# Altium schematic sheet Analog - Current_Probe_V0.2.SchDoc
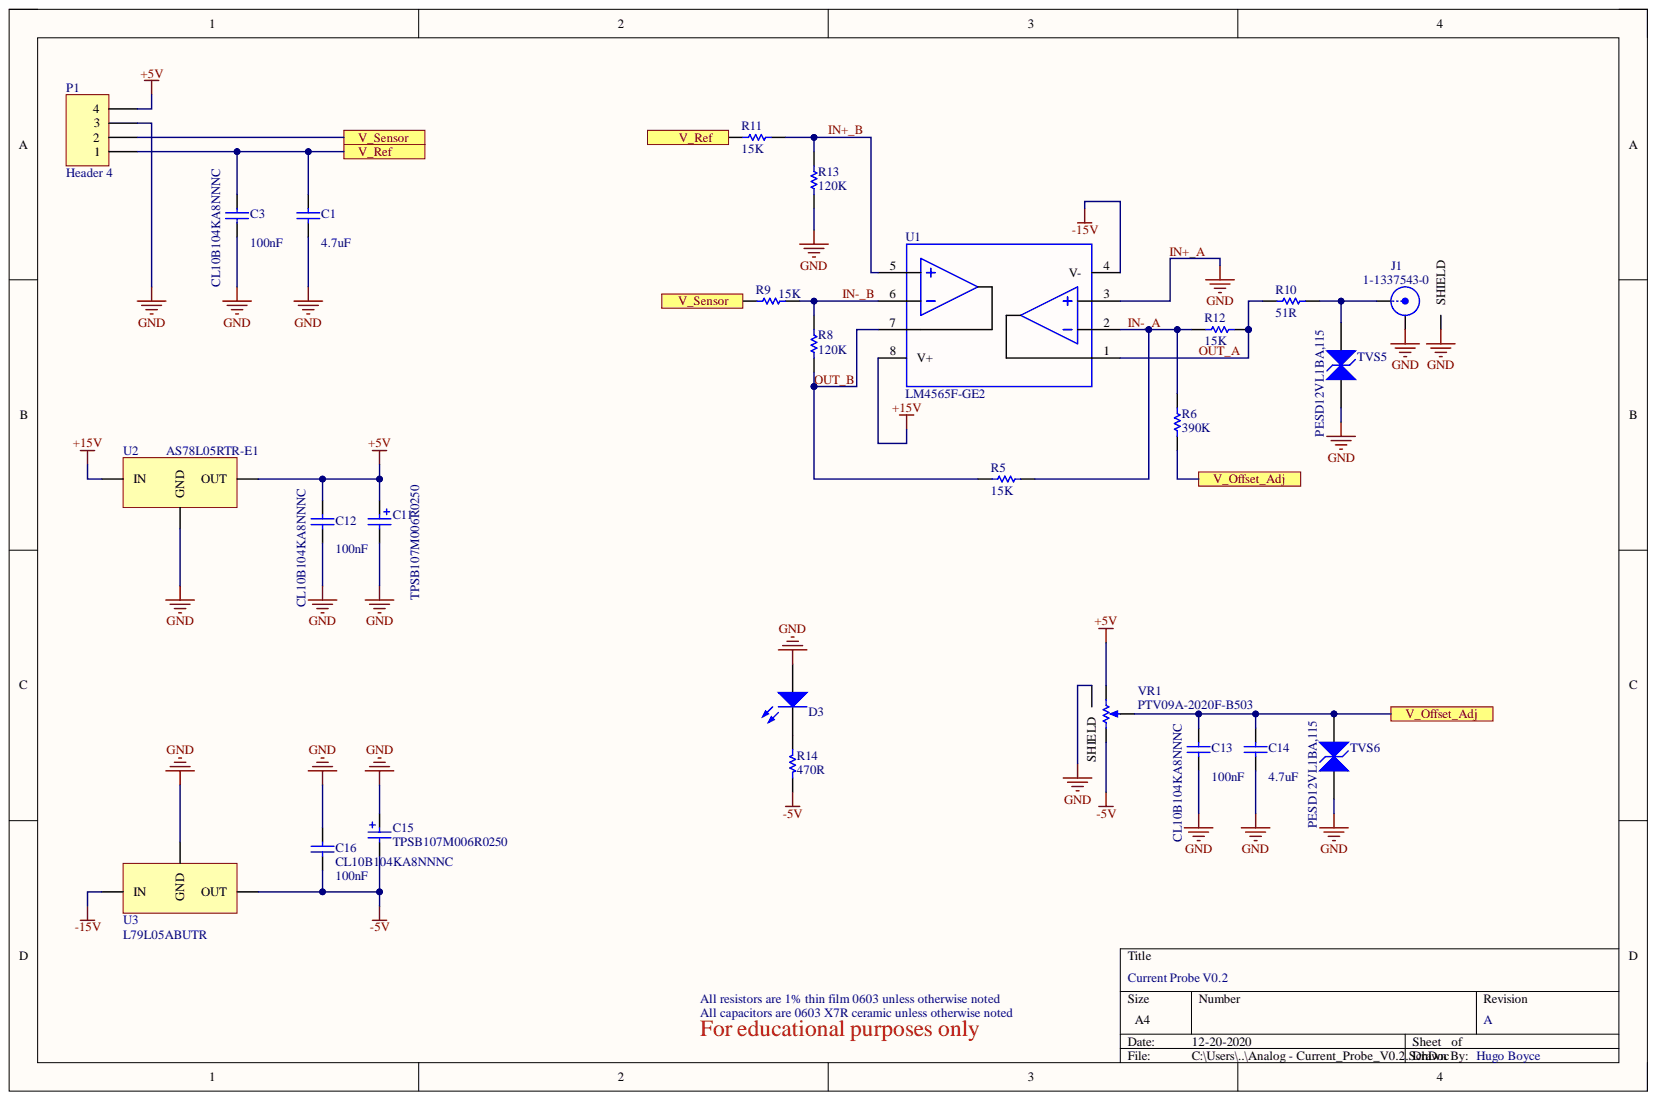
[diagram p0: Analog - Current_Probe_V0.2.SchDoc]

## Parts
C1  value 4.7uF  [CAPC3216N]  (hidden comment: CL31B475KAHNNNE)
C3  CL10B104KA8NNNC  value 100nF  [CAPC1608N]
C11  TPSB107M006R0250  [CAPMP3529X21N]
C12  CL10B104KA8NNNC  value 100nF  [CAPC1608N]
C13  CL10B104KA8NNNC  value 100nF  [CAPC1608N]
C14  value 4.7uF  [CAPC3216N]  (hidden comment: CL31B475KAHNNNE)
C15  TPSB107M006R0250  [CAPMP3529X21N]
C16  CL10B104KA8NNNC  value 100nF  [CAPC1608N]
D3  150120RS75000  [150120RS75000]  (value not drawn)
J1  1-1337543-0  [1-1337543-0]
P1  Header 4  [HDR1X4]
R5  value 15K  [RESC1608N]  (hidden comment: Res1)
R6  value 390K  [RESC1608N]  (hidden comment: Res1)
R8  value 120K  [RESC1608N]  (hidden comment: Res1)
R9  value 15K  [RESC1608N]  (hidden comment: Res1)
R10  value 51R  [RESC3225N]  (hidden comment: Res1)
R11  value 15K  [RESC1608N]  (hidden comment: Res1)
R12  value 15K  [RESC1608N]  (hidden comment: Res1)
R13  value 120K  [RESC1608N]  (hidden comment: Res1)
R14  value 470R  [RESC1608N]  (hidden comment: Res1)
TVS5  PESD12VL1BA,115  [SODFL260X100-2N]
TVS6  PESD12VL1BA,115  [SODFL260X100-2N]
U1  LM4565F-GE2  [SOIC8_LM4565]
U2  AS78L05RTR-E1  [SOT89N]
U3  L79L05ABUTR  [SOT89N]
VR1  PTV09A-2020F-B503  [PTV09A-2x20F]

## Ports
V_Offset_Adj, V_Ref, V_Sensor

## Nets
+5V: C11.1 C12.2 P1.4 U2.1 VR1.3
+15V: U1.8(V+) U2.3
-5V: C15.2 C16.2 R14.1 U3.3 VR1.1
-15V: U1.4(V-) U3.2
GND: C1.1 C3.1 C11.2 C12.1 C13.1 C14.1 C15.1 C16.1 D3.2 J1.2 J1.3 P1.3 R13.1 TVS5.A TVS6.A U1.3 U2.2 U3.1 VR1.4
IN+_B: R11.1 R13.2 U1.5
IN-_A: R5.1 R6.1 R12.1 U1.2
IN-_B: R8.1 R9.1 U1.6
OUT_A: R10.1 R12.2 U1.1
OUT_B: R5.2 R8.2 U1.7
V_Offset_Adj: C13.2 C14.2 R6.2 TVS6.K VR1.2 ; port V_Offset_Adj
V_Ref: C1.2 C3.2 P1.1 R11.2 ; port V_Ref
V_Sensor: P1.2 R9.2 ; port V_Sensor
NetD3_1: D3.1 R14.2
NetJ1_1: J1.1 R10.2 TVS5.K
IN+_A:

## Library data of the parts
C1 C14 = CL31B475KAHNNNE: Cap Ceramic 4.7uF 25V X7R 10% SMD 1206 125C Embossed T/R; Manufacturer: Samsung; Capacitance: 4700000 pF; Case Size/Dimension: 1206; Component Kind: Standard; ComponentLink1Description: http://www.samsungsem.com/kr/support/product-search/mlcc/CL31B475KAHNNNE.jsp?src-supplier=Digi-Key; ComponentLink1URL: http://www.samsungsem.com/kr/support/product-search/mlcc/CL31B475KAHNNNE.jsp?src-supplier=Digi-Key; ComponentLink2Description: https://www.distrelec.biz/Web/Downloads/_e/ng/MLCC_CatalogDownload2013_ENG.pdf?mime=application%2Fpdf&src-supplier=Distrelec; ComponentLink2URL: https://www.distrelec.biz/Web/Downloads/_e/ng/MLCC_CatalogDownload2013_ENG.pdf?mime=application%2Fpdf&src-supplier=Distrelec; ComponentLink3Description: http://www1.futureelectronics.com/doc/SAMSUNG/CL10C1R5BB8NNNC.pdf?src-supplier=Future+Electronics; ComponentLink3URL: http://www1.futureelectronics.com/doc/SAMSUNG/CL10C1R5BB8NNNC.pdf?src-supplier=Future+Electronics; ComponentLink4Description: http://www.produktinfo.conrad.com/datenblaetter/450000-474999/452772-da-01-en-VS_KONDENSATOR_1206.pdf?src-supplier=Conrad; ComponentLink4URL: http://www.produktinfo.conrad.com/datenblaetter/450000-474999/452772-da-01-en-VS_KONDENSATOR_1206.pdf?src-supplier=Conrad; ComponentLink5Description: http://www.produktinfo.conrad.com/datenblaetter/450000-474999/452785-da-01-en-VS_KONDENSATOR_1206.pdf?src-supplier=Conrad; ComponentLink5URL: http://www.produktinfo.conrad.com/datenblaetter/450000-474999/452785-da-01-en-VS_KONDENSATOR_1206.pdf?src-supplier=Conrad; Dielectric: X7R; Manufacturer Part Number: CL31B475KAHNNNE; Pricing 1: 1=0.22, 10=0.152, 50=0.0912, 100=0.077, 500=0.0527, 1000=0.0466 (USD); Rated Voltage: 25 V; Stock 1: 137774; Supplier 1: Digi-Key; Supplier Part Number 1: 1276-1055-1-ND; Tolerance (%): ±10 %; Library Name: Current_Probe_Library.SchLib
C3 C12 C13 C16 = CL10B104KA8NNNC: CAP CER 0.1UF 25V X7R 0603; Manufacturer: Samsung; Capacitance: 100000 pF; Tolerance (%): ±10 %; Case Size/Dimension: 0603; Component Kind: Standard; ComponentLink1Description: http://www.samsungsem.com/kr/support/product-search/mlcc/__icsFiles/afieldfile/2018/08/29/Data%20sheet_CL10B104KA8NNNC.pdf?src-supplier=Digi-Key; ComponentLink1URL: http://www.samsungsem.com/kr/support/product-search/mlcc/__icsFiles/afieldfile/2018/08/29/Data%20sheet_CL10B104KA8NNNC.pdf?src-supplier=Digi-Key; ComponentLink2Description: https://www.verical.com/server-webapp/html/getDatasheet?partID=354050&src-supplier=Verical; ComponentLink2URL: https://www.verical.com/server-webapp/html/getDatasheet?partID=354050&src-supplier=Verical; Dielectric: X7R; Manufacturer Part Number: CL10B104KA8NNNC; Pricing 1: 1=0.1, 10=0.029, 50=0.016, 100=0.013, 500=0.0093, 1000=0.0073 (USD); Rated Voltage: 25 V; Stock 1: 4133737; Supplier 1: Digi-Key; Supplier Part Number 1: 1276-1006-1-ND; Library Name: Current_Probe_Library.SchLib
C11 C15 = TPSB107M006R0250: CAP TANT 100UF 20% 6.3V 1411; Manufacturer: Kyocera AVX; Manufacturer Size Code: B; Capacitance: 100µF; ESR (Equivalent Series Resistance): 250 mOhm; Featured Product: TPS Capacitors; Online Catalog: TPS Series; Other Names: 478-4978-6; Product Training Modules: Component Solutions for Smart Meter Applications; Type: Molded; Category: Capacitors; Component Kind: Standard; ComponentLink1Description: http://datasheets.avx.com/TPS.pdf?src-supplier=Digi-Key; ComponentLink1URL: http://datasheets.avx.com/TPS.pdf?src-supplier=Digi-Key; ComponentLink2Description: http://www.avx.com/docs/catalogs/tps.pdf?src-supplier=Avnet; ComponentLink2URL: http://www.avx.com/docs/catalogs/tps.pdf?src-supplier=Avnet; ComponentLink3Description: http://www.avxcorp.com/docs/Catalogs/tps.pdf?src-supplier=Future+Electronics; ComponentLink3URL: http://www.avxcorp.com/docs/Catalogs/tps.pdf?src-supplier=Future+Electronics; ComponentLink4Description: http://www.avx.com/docs/catalogs/tps.pdf?src-supplier=Avnet+Europe; ComponentLink4URL: http://www.avx.com/docs/catalogs/tps.pdf?src-supplier=Avnet+Europe; Family: Tantalum Capacitors; Features: General Purpose; Height - Seated (Max): 0.083" (2.10mm); Lead Spacing: -; Lifetime @ Temp.: -; Manufacturer Part Number: TPSB107M006R0250; Mounting Type: Surface Mount; Operating Temperature: -55°C ~ 125°C; Package / Case: 1210 (3528 Metric); Packaging: Digi-Reel®; Pricing 1: 1=0.65, 10=0.478, 100=0.3279, 500=0.2596, 1000=0.2186 (USD); Series: TPS; Size / Dimension: 0.138" L x 0.110" W (3.50mm x 2.80mm); Standard Package: 1; Stock 1: 4274; Supplier 1: Digi-Key; Supplier Part Number 1: 478-4978-1-ND; Tolerance: ±20%; Voltage - Rated: 6.3V; Library Name: Current_Probe_Library.SchLib
D3 = 150120RS75000: LED RED CLEAR 1206 SMD; Manufacturer: Wurth Electronics Inc.; Current - Test: 20mA; Lens Color: Colorless; Lens Style/Size: Rectangle with Flat Top, 2.00mm x 1.60mm; Millicandela Rating: 150mcd; Viewing Angle: 140°; Voltage - Forward (Vf) (Typ): 2V; Wavelength - Dominant: 625nm; Wavelength - Peak: 630nm; Category: Optoelectronics; Color: Red; Component Kind: Standard; ComponentLink1Description: http://katalog.we-online.de/led/datasheet/150120RS75000.pdf; ComponentLink1URL: http://katalog.we-online.de/led/datasheet/150120RS75000.pdf; Features: -; Height (Max): 0.69mm; Lens Transparency: Clear; Manufacturer Part Number: 150120RS75000; Mounting Type: Surface Mount; Package / Case: 1206 (3216 Metric); Packaging: Cut Tape (CT); Part Status: Active; Pricing 1: 1=0.27, 50=0.2582, 100=0.2211, 500=0.18952 (CAD); RoHS: RoHS Compliant; Size / Dimension: 3.20mm L x 1.60mm W; Stock 1: 14076; Supplier 1: Digi-Key; Supplier Device Package: 1206; Supplier Part Number 1: 732-4991-1-ND; Library Name: Current_Probe_Library.SchLib
J1 = 1-1337543-0: Conn ZDC/BNC RCP 0Hz to 4GHz 50Ohm Solder RA Thru-Hole Gold Over Nickel Over Copper Individual; Manufacturer: TE Connectivity; Connector Body Style: Right Angle Jack; Connector Mounting: PCB Mount; Connector Type: BNC Coaxial; SVHC: To Be Advised; Coaxial Cable Types: -; Coaxial Termination: Solder; Component Kind: Standard; ComponentLink1Description: http://www.farnell.com/datasheets/580087.pdf?src-supplier=Farnell; ComponentLink1URL: http://www.farnell.com/datasheets/580087.pdf?src-supplier=Farnell; ComponentLink2Description: http://www.farnell.com/datasheets/580087.pdf?src-supplier=Element14; ComponentLink2URL: http://www.farnell.com/datasheets/580087.pdf?src-supplier=Element14; ComponentLink3Description: http://www.alliedelec.com/m/d/1f9c8ff8fec8b9d66c5116e4363293bb.pdf?src-supplier=Allied+Electronics; ComponentLink3URL: http://www.alliedelec.com/m/d/1f9c8ff8fec8b9d66c5116e4363293bb.pdf?src-supplier=Allied+Electronics; ComponentLink4Description: http://www.te.com/commerce/DocumentDelivery/DDEController?Action=showdoc&DocId=Data+Sheet%7F6-1773448-5_ZDC_RF_COAX_50-75_OHM%7F1007%7Fpdf%7FEnglish%7FENG_DS_6-1773448-5_ZDC_RF_COAX_50-75_OHM_1007.pdf&src-supplier=Avnet; ComponentLink4URL: http://www.te.com/commerce/DocumentDelivery/DDEController?Action=showdoc&DocId=Data+Sheet%7F6-1773448-5_ZDC_RF_COAX_50-75_OHM%7F1007%7Fpdf%7FEnglish%7FENG_DS_6-1773448-5_ZDC_RF_COAX_50-75_OHM_1007.pdf&src-supplier=Avnet; ComponentLink5Description: https://www.te.com/commerce/DocumentDelivery/DDEController?Action=srchrtrv&DocNm=1337543&DocType=Customer+Drawing&DocLang=English&src-supplier=Digi-Key; ComponentLink5URL: https://www.te.com/commerce/DocumentDelivery/DDEController?Action=srchrtrv&DocNm=1337543&DocType=Customer+Drawing&DocLang=English&src-supplier=Digi-Key; ComponentLink6Description: http://www.te.com/commerce/DocumentDelivery/DDEController?Action=showdoc&DocId=Data+Sheet%7F6-1773448-5_ZDC_RF_COAX_50-75_OHM%7F1007%7Fpdf%7FEnglish%7FENG_DS_6-1773448-5_ZDC_RF_COAX_50-75_OHM_1007.pdf&src-supplier=Avnet+Europe; ComponentLink6URL: http://www.te.com/commerce/DocumentDelivery/DDEController?Action=showdoc&DocId=Data+Sheet%7F6-1773448-5_ZDC_RF_COAX_50-75_OHM%7F1007%7Fpdf%7FEnglish%7FENG_DS_6-1773448-5_ZDC_RF_COAX_50-75_OHM_1007.pdf&src-supplier=Avnet+Europe; ComponentLink7Description: http://www.onlinecomponents.com/productFiles/mf-AMP/eng_ds_6-1773448-5_zdc_rf_coax_50-75_ohm_1007.pdf?src-supplier=Onlinecomponents.com; ComponentLink7URL: http://www.onlinecomponents.com/productFiles/mf-AMP/eng_ds_6-1773448-5_zdc_rf_coax_50-75_ohm_1007.pdf?src-supplier=Onlinecomponents.com; Contact Material: Brass; Contact Plating: Gold Plated Contacts; Frequency Max: 4GHz; Impedance: 50ohm; Manufacturer Part Number: 1-1337543-0; Pricing 1: 1=1.67, 10=1.514, 25=1.4212, 50=1.3592, 100=1.2975, 250=1.1739, 500=1.0812, 1000=0.9268 (USD); Product Range: -; Stock 1: 4565; Supplier 1: Digi-Key; Supplier Part Number 1: A97553-ND; Library Name: Current_Probe_Library.SchLib
P1 = Header 4: Header, 4-Pin; Component Kind: Standard; LatestRevisionDate: 17-Jul-2002; LatestRevisionNote: Re-released for DXP Platform.; Library Name: Miscellaneous Connectors.IntLib; Signal Integrity: Connector; Publisher: Altium Limited
R5 R6 R8-R14 = Res1: Resistor; Component Kind: Standard; LatestRevisionDate: 17-Jul-2002; LatestRevisionNote: Re-released for DXP Platform.; Library Name: Miscellaneous Devices.SchLib; PackageDescription: Axial Device, Thru-Hole; 2 Leads; 0.3 in Pin Spacing; PackageReference: AXIAL-0.3; Published: 8-Jun-2000; Signal Integrity: Res; Simulation: RESISTOR; Publisher: Altium Limited
TVS5 TVS6 = PESD12VL1BA,115: TVS DIODE 12V 37V SOD323; Manufacturer: Nexperia; Component Kind: Standard; ComponentLink1Description: http://www.farnell.com/datasheets/682594.pdf?src-supplier=Farnell; ComponentLink1URL: http://www.farnell.com/datasheets/682594.pdf?src-supplier=Farnell; ComponentLink2Description: https://assets.nexperia.com/documents/data-sheet/PESD24VL1BA.pdf?src-supplier=Digi-Key; ComponentLink2URL: https://assets.nexperia.com/documents/data-sheet/PESD24VL1BA.pdf?src-supplier=Digi-Key; Manufacturer Part Number: PESD12VL1BA,115; Pricing 1: 1=0.43, 10=0.28, 100=0.156, 500=0.104, 1000=0.072 (USD); Stock 1: 207; Supplier 1: Digi-Key; Supplier Part Number 1: 1727-3808-1-ND; Library Name: Current_Probe_Library.SchLib
U1 = LM4565F-GE2: Op Amp Dual Low Noise Amplifier ±18V 8-Pin SOP T/R; Manufacturer: Rohm; Amplifier Type: General Purpose; Current - Input Bias: 70nA; Current - Output / Channel: 160mA; Current - Supply: 4.5mA; Gain Bandwidth Product: 10MHz; Number of Circuits: 2; Slew Rate: 5 V/µs; Voltage - Input Offset: 500µV; Voltage - Supply, Single/Dual (±): 4 V ~ 36 V, ±2 V ~ 18 V; -3db Bandwidth: -; Category: Integrated Circuits (ICs); Component Kind: Standard; ComponentLink1Description: http://rohmfs.rohm.com/en/products/databook/datasheet/ic/amp_linear/opamp/lm4565f-e.pdf?src-supplier=Digi-Key; ComponentLink1URL: http://rohmfs.rohm.com/en/products/databook/datasheet/ic/amp_linear/opamp/lm4565f-e.pdf?src-supplier=Digi-Key; ComponentLink2Description: http://rohmfs.rohm.com/en/products/databook/datasheet/ic/amp_linear/opamp/lm4565f-e.pdf?src-supplier=Avnet; ComponentLink2URL: http://rohmfs.rohm.com/en/products/databook/datasheet/ic/amp_linear/opamp/lm4565f-e.pdf?src-supplier=Avnet; ComponentLink3Description: http://www.mouser.com/ds/2/348/lm4565f-e-372180.pdf?src-supplier=Mouser; ComponentLink3URL: http://www.mouser.com/ds/2/348/lm4565f-e-372180.pdf?src-supplier=Mouser; Family: Linear - Amplifiers - Instrumentation, OP Amps, Buffer Amps; Manufacturer Part Number: LM4565F-GE2; Mounting Type: Surface Mount; Operating Temperature: -40°C ~ 85°C; Output Type: -; Package / Case: 8-SOIC (0.173", 4.40mm Width); Packaging: Tape & Reel (TR); Pricing 1: 1=0.45, 10=0.385, 25=0.3592, 100=0.2668, 250=0.2257, 500=0.2155, 1000=0.159 (USD); Series: -; Stock 1: 1588; Supplier 1: Digi-Key; Supplier Device Package: 8-SOP; Supplier Part Number 1: LM4565F-GE2CT-ND; Library Name: Current_Probe_Library.SchLib
U2 = AS78L05RTR-E1: IC REG LINEAR 5V 100MA SOT89-3; Manufacturer: Diodes; Accuracy: 5 %; Function: Standard; Junction to Ambient: 180 C/W; Junction to Case: 28.3 C/W; Line Regulation: 150 mV; Load Regulation: 60 mV; Max Processing Temp: 260; Maximum Input Voltage: 30 V; Maximum Output Current: 0.1 A; Maximum Power Dissipation: 750 mW; Maximum Quiescent Current: 5.5 mA; Number of Outputs: 1; Output Type: Fixed; Polarity: Positive; Screening Level: Extended Industrial; Typical Dropout Voltage @ Current: 1.7@40mA|1.8@100mA V; Typical Power Dissipation: 0.75 W; Typical Quiescent Current: 3 mA; Component Kind: Standard; ComponentLink1Description: http://www.diodes.com/datasheets/AS78Lxx.pdf?src-supplier=Avnet; ComponentLink1URL: http://www.diodes.com/datasheets/AS78Lxx.pdf?src-supplier=Avnet; Manufacturer Part Number: AS78L05RTR-E1; Mounting: Surface Mount; Operating Temperature: -40 to 125 C; Output Voltage: 5 V; Pricing 1: 1=0.37, 10=0.277, 25=0.25, 100=0.1364, 250=0.1318, 500=0.1182 (USD); Stock 1: 12160; Supplier 1: Digi-Key; Supplier Part Number 1: AS78L05RTR-E1DICT-ND; Library Name: Current_Probe_Library.SchLib
U3 = L79L05ABUTR: STMICROELECTRONICS - L79L05ABUTR - Régulateur de tension Linéaire, 7905, Fixe, -20V à -8V In, -5V et 0.1A Out, SOT-89-3; Dropout Voltage@Current (Typ): 1.7@40mA; Input Voltage: -30V; Line Regulation: 150mV; Load Regulation: 60mV; Number of Outputs: 1; Output Current: 100mA; Polarity Type: Negative; Quiescent Current (Max): 6mA; Regulator Output Type: Fixed; Topology: Standard; Component Kind: Standard; ComponentLink1Description: https://media.digikey.com/pdf/Data%20Sheets/ST%20Microelectronics%20PDFS/L79L.pdf?src-supplier=Digi-Key; ComponentLink1URL: https://media.digikey.com/pdf/Data%20Sheets/ST%20Microelectronics%20PDFS/L79L.pdf?src-supplier=Digi-Key; ComponentLink2Description: http://www.st.com/st-web-ui/static/active/en/resource/technical/document/datasheet/CD00000539.pdf?src-supplier=Future+Electronics; ComponentLink2URL: http://www.st.com/st-web-ui/static/active/en/resource/technical/document/datasheet/CD00000539.pdf?src-supplier=Future+Electronics; ComponentLink3Description: http://www.st.com/web/en/resource/technical/document/datasheet/CD00000539.pdf?src-supplier=Avnet; ComponentLink3URL: http://www.st.com/web/en/resource/technical/document/datasheet/CD00000539.pdf?src-supplier=Avnet; ComponentLink4Description: http://www.st.com/web/en/resource/technical/document/datasheet/CD00000539.pdf?src-supplier=Avnet+Europe; ComponentLink4URL: http://www.st.com/web/en/resource/technical/document/datasheet/CD00000539.pdf?src-supplier=Avnet+Europe; Manufacturer: STMicroelectronics; Manufacturer Part Number: L79L05ABUTR; Mounting: Surface Mount; Operating Temp Range: -40C to 125C; Operating Temperature Classification: Automotive; Output Voltage: -5V; Package Type: SOT-89; Packaging: Tape and Reel; Pin Count: 3 +Tab; Pricing 1: 1=0.43, 10=0.352, 25=0.322, 100=0.2172, 250=0.1798, 500=0.1648, 1000=0.1236 (USD); Stock 1: 7264; Supplier 1: Digi-Key; Supplier Part Number 1: 497-1217-1-ND; Voltage Regulator Type: Standard; Library Name: Current_Probe_Library.SchLib
VR1 = PTV09A-2020F-B503: Potentiometers 50K LINEAR 20%; Manufacturer: Bourns; Number of Turns: 1(Elec)|1(Mech) Turns; Power Rating: 1/20 W; Rad Hard: No; Resistance Value: 50 KOhm; Shaft Diameter: 6 mm; Component Kind: Standard; Manufacturer Part Number: PTV09A-2020F-B503; Mounting: Bracket Mount/Through Hole; Operating Temperature: -10 to 50 °C; Packaging: Bulk; Pricing 1: 1=0.83, 10=0.744, 25=0.672, 50=0.648, 100=0.612, 250=0.588, 500=0.552, 1000=0.456, 5000=0.372 (USD); Stock 1: 442; Supplier 1: Digi-Key; Supplier Part Number 1: PTV09A-2020F-B503-ND; Technology: Carbon Film; Tolerance: 20 %; Type: Potentiometer; Library Name: Current_Probe_Library.SchLib
